# Altium schematic sheet Célula 2.SchDoc
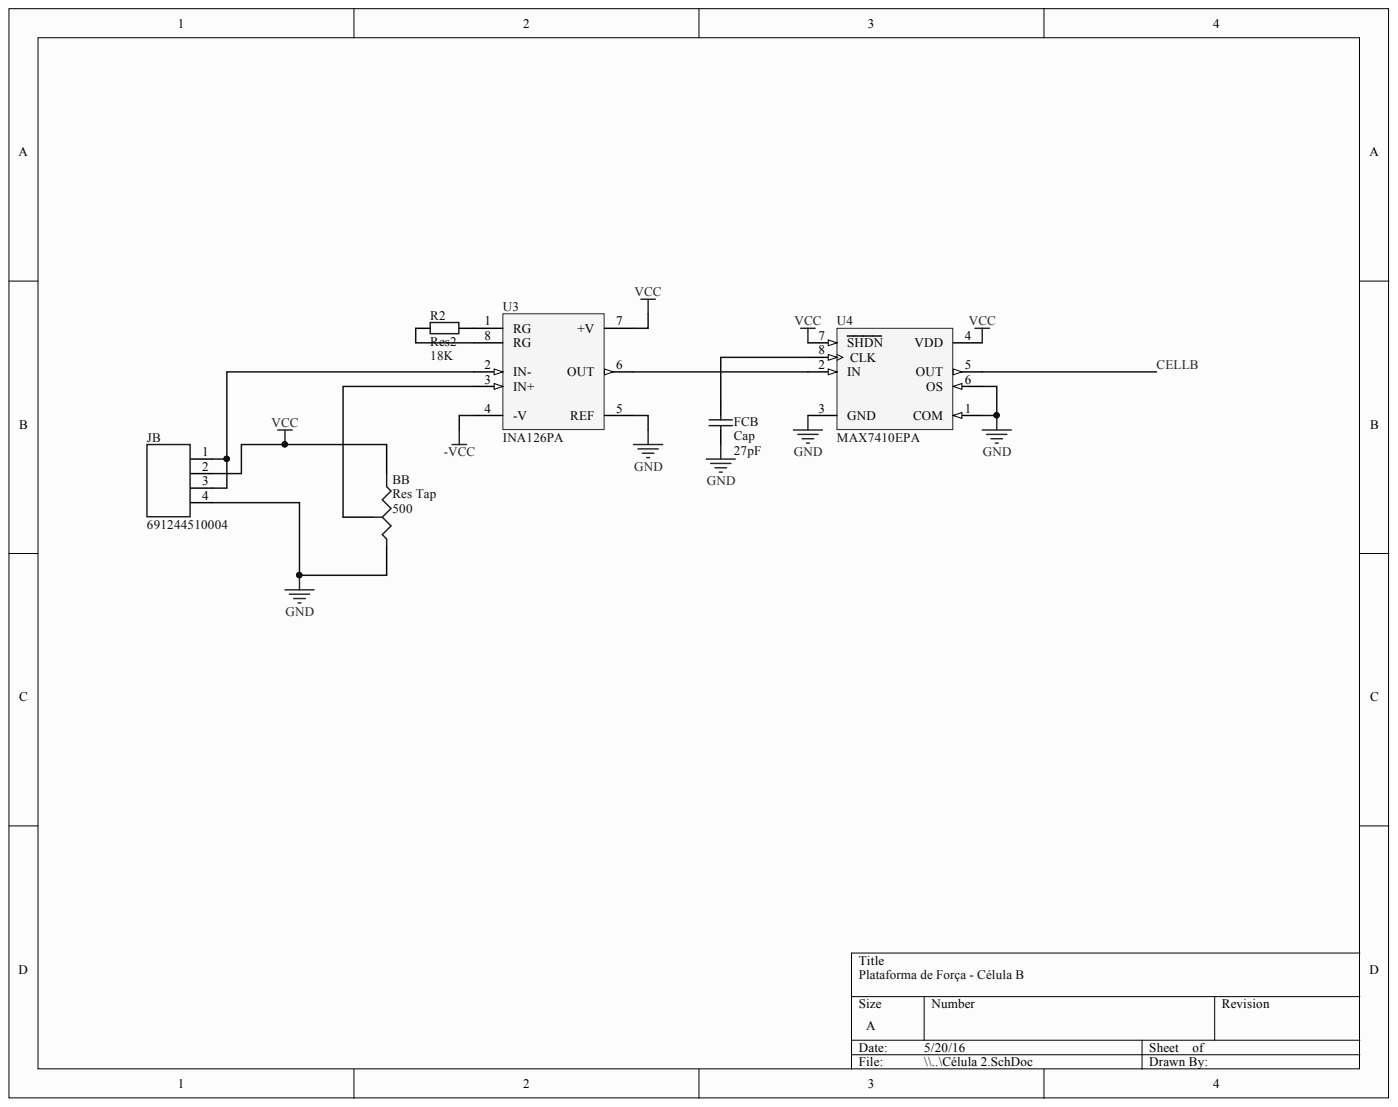
[diagram p0: Célula 2.SchDoc]

## Parts
BB  Res Tap  value 500  [TSR3006]
FCB  Cap  value 27pF  [RAD-0.3]
JB  691244510004  [691244510004]
R2  Res2  value 18K  [AXIAL-0.4]
U3  INA126PA  [006E]
U4  MAX7410EPA  [PA8]

## Nets
-VCC: U3.4(-V)
GND: BB.1 FCB.1 JB.4 U3.5(REF) U4.1(COM) U4.3(GND) U4.6(OS)
VCC: BB.2 JB.2 U3.7(+V) U4.4(VDD) U4.7(SHDN)
CELLB: U4.5(OUT)
NetBB_3: BB.3 U3.3(IN+)
NetFCB_2: FCB.2 U4.8(CLK)
NetJB_1: JB.1 JB.3 U3.2(IN-)
NetR2_1: R2.1 U3.8(RG)
NetR2_2: R2.2 U3.1(RG)
NetU3_6: U3.6(OUT) U4.2(IN)

## Library data of the parts
BB = Res Tap: Tapped Resistor; Set Position: 0.5; Sim Note: Enter the Resistance value and the set point into parameter fields.; Component Kind: Standard; LatestRevisionDate: 17-Jul-2002; LatestRevisionNote: Re-released for DXP Platform.; Library Name: Miscellaneous Devices.IntLib; PackageDescription: Variable Resistor, Thru-Hole; 3 Leads; PackageReference: VR3; Published: 24-Mar-1999; Signal Integrity: Res Tap; Simulation: POT; Publisher: Altium Limited
FCB = Cap: Capacitor; Component Kind: Standard; LatestRevisionDate: 17-Jul-2002; LatestRevisionNote: Re-released for DXP Platform.; Library Name: Miscellaneous Devices.IntLib; PackageDescription: Radial Cap, Thru-Hole; 2 Leads; 0.3 in Pin Spacing; PackageReference: RAD-0.3; Published: 8-Jun-2000; Signal Integrity: Cap; Simulation: CAP; Publisher: Altium Limited
JB = 691244510004: WR-TBL Horizontal Cable Entry With Rising Cage Clamp,Serie 2445 - 5.08 mm; Manufacturer: Wurth Elektronik; ComponentLink1 Description: Manufacturer; ComponentLink2 Description: Datasheet; Component Kind: Standard; ComponentLink1URL: http://www.we-online.de; ComponentLink2URL: http://katalog.we-online.de/em/datasheet/6912445100XX.pdf; Created Date: 15.Sep.2015; Library Name: WE_WR-TBL_Rising Cage System_5.08 mm_rev15b.IntLib; partNo: 691244510004; Created by: Baojun Chen
R2 = Res2: Resistor; Component Kind: Standard; LatestRevisionDate: 17-Jul-2002; LatestRevisionNote: Re-released for DXP Platform.; Library Name: Miscellaneous Devices.IntLib; PackageDescription: Axial Device, Thru-Hole; 2 Leads; 0.4 in Pin Spacing; PackageReference: AXIAL-0.4; Published: 8-Jun-2000; Signal Integrity: Res; Simulation: RESISTOR; Publisher: Altium Limited
U3 = INA126PA: Precision, Low-Power Instrumentation Amplifiers; Component Kind: Standard; ComponentLink1Description: Manufacturer Link; ComponentLink1URL: http://www.ti.com/; ComponentLink2Description: Datasheet; ComponentLink2URL: http://www-s.ti.com/sc/ds/INA128.pdf; DatasheetVersion: Oct-1996; LatestRevisionDate: 06-Jun-2005; LatestRevisionNote: Stylized 3D Model Added.; PackageDescription: DIP; 8 Leads; Row Spacing 7.62 mm; Pitch 2.54 mm; PackageReference: 006E; PackageVersion: revE; PCB3D: DIP8; Published: 8-Jun-2000; Library Name: Placa.SCHLIB; Publisher: Altium Limited
U4 = MAX7410EPA: 5th-Order, Lowpass, Switched-Capacitor Filter; Code_JEDEC: MS-001-AB; Component Kind: Standard; ComponentLink1Description: Manufacturer Link; ComponentLink1URL: http://www.maxim-ic.com/; ComponentLink2Description: Datasheet; ComponentLink2URL: http://pdfserv.maxim-ic.com/en/ds/MAX7409-MAX7414.pdf; DatasheetVersion: Sep-1998; LatestRevisionDate: 29-May-2009; LatestRevisionNote: Component Body Added.; Library Name: Maxim Filter Switched Capacitor.IntLib; PackageDescription: DIP, 8-Pin, Row Spacing 7.62 mm, Pitch 2.54 mm; PackageReference: PA8; PackageVersion: RevB, 21-0043; PCB3D: PA8; Published: 16-Jan-2001; Publisher: Altium Limited
